# Altium schematic sheet Sheet1.SchDoc(Sheet1)
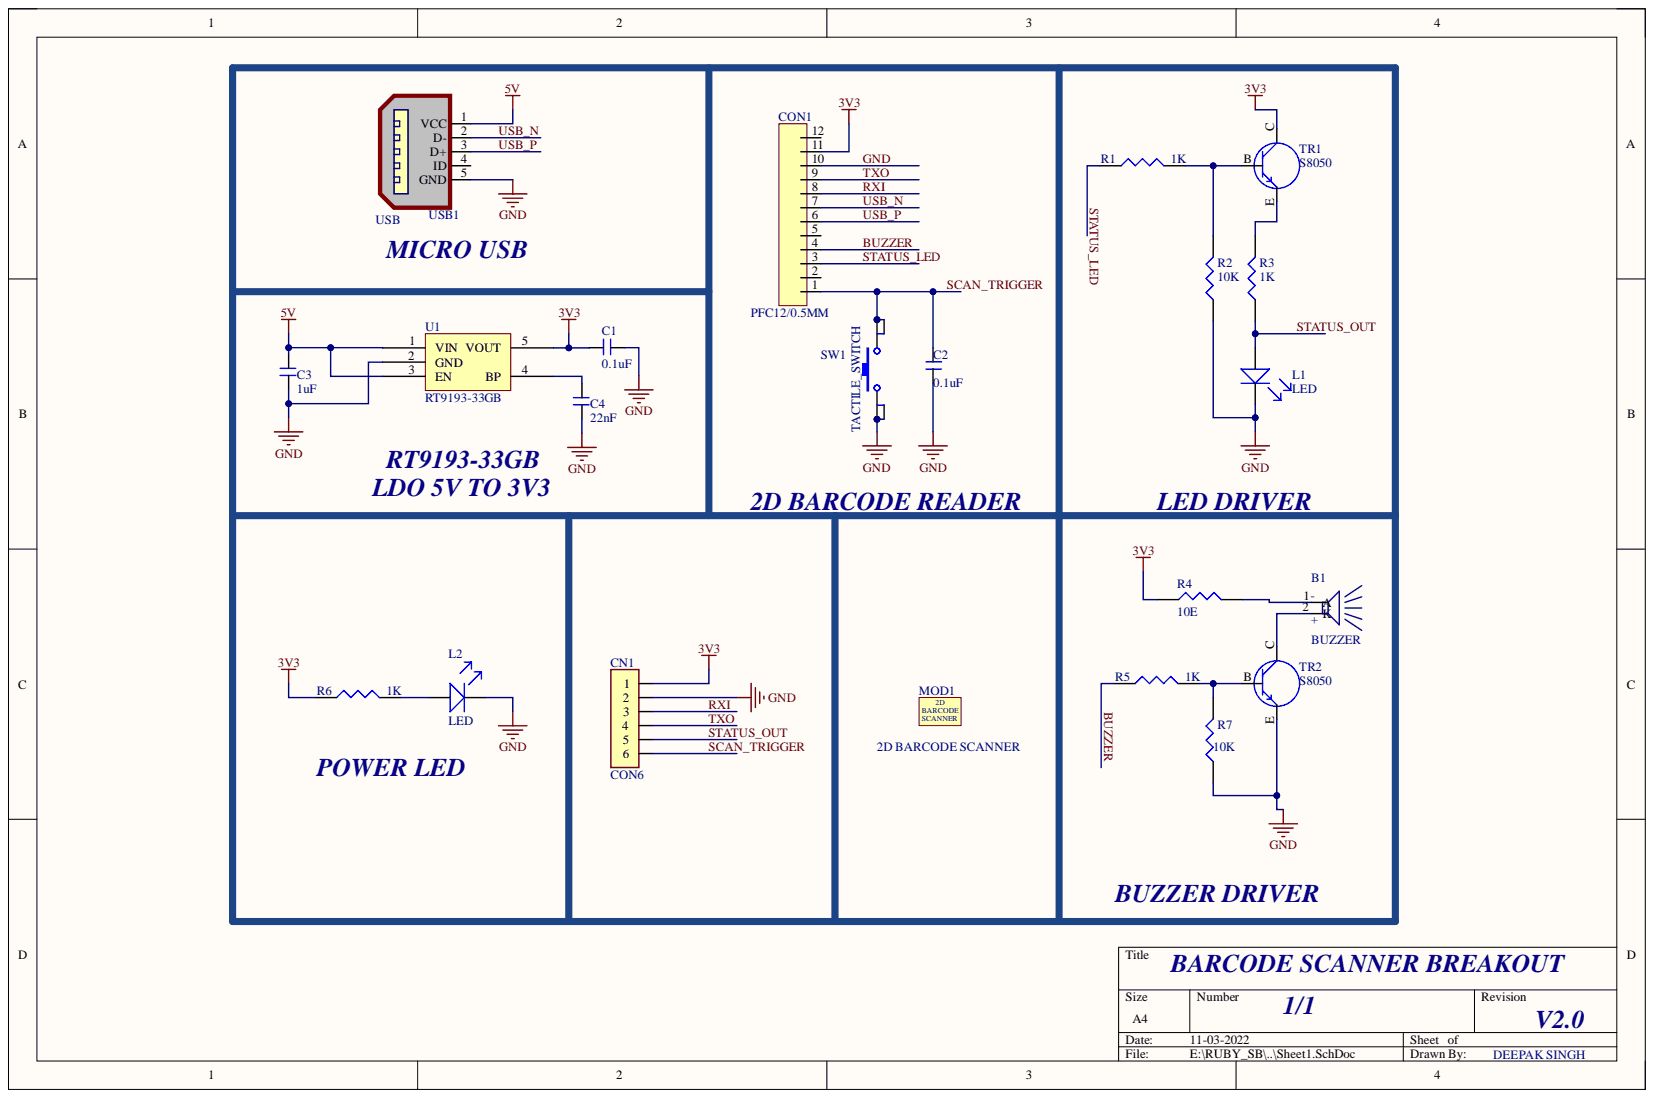
[diagram p0: Sheet1.SchDoc]

## Parts
B1  BUZZER  [BUZZER_9*3.2 SMD]
C1  0.1uF  [CAP_0603_NONPOLAR]
C2  0.1uF  [CAP_0603_NONPOLAR]
C3  1uF  [CAP_0603_NONPOLAR]
C4  22nF  [CAP_0603_NONPOLAR]
CN1  CON6  [CON6_BJ]
CON1  PFC12/0.5MM  [FPC CONNECTOR -12PIN]
L1  LED  [LED_0603_RED]
L2  LED  [LED_0603_RED]
MOD1  2D BARCODE SCANNER  [2D BARCODE SCANNER]
R1  1K  [0603]
R2  10K  [0603]
R3  1K  [0603]
R4  10E  [0603]
R5  1K  [0603]
R6  1K  [0603]
R7  10K  [0603]
SW1  TACTILE_SWITCH  [SKRPACE010]
TR1  S8050  [547_SOT23]
TR2  S8050  [547_SOT23]
U1  RT9193-33GB  [SOT-23-5]
USB1  USB  [USB_MICRO 2]

## Nets
3V3: C1.2 CN1.1 CON1.11 R4.1 R6.1 TR1.C U1.5(VOUT)
5V: C3.1 U1.1(VIN) U1.3(EN) USB1.1(VCC)
BUZZER: CON1.4 R5.1
GND: C1.1 C2.1 C3.2 C4.2 CN1.2 CON1.10 L1.K L2.K R2.1 R7.1 SW1.1 SW1.2 TR2.E U1.2(GND) USB1.5(GND)
RXI: CN1.3 CON1.8
SCAN_TRIGGER: C2.2 CN1.6 CON1.1 SW1.3 SW1.4
STATUS_LED: CON1.3 R1.1
STATUS_OUT: CN1.5 L1.A R3.1
TXO: CN1.4 CON1.9
USB_N: CON1.7 USB1.2(D-)
USB_P: CON1.6 USB1.3(D+)
NetB1_1: B1.1 R4.2
NetB1_2: B1.2 TR2.C
NetC4_1: C4.1 U1.4(BP)
NetL2_A: L2.A R6.2
NetR1_2: R1.2 R2.2 TR1.B
NetR3_2: R3.2 TR1.E
NetR5_2: R5.2 R7.2 TR2.B

Not connected: CON1 2 5 12; USB1 4(ID)

## Library data of the parts
B1 = BUZZER PIEZO: Component Kind: Standard; Library Name: dxp_pasl_lib.IntLib
C1-C4 = CAP_NONPOLAR: Component Kind: Standard; Library Name: dxp_pasl_lib.IntLib
R1-R7 = RES: Component Kind: Standard; Library Name: dxp_pasl_lib.IntLib
TR1 TR2 = TRANSISTER_NPN: Component Kind: Standard; Library Name: dxp_pasl_lib.IntLib
U1 = RT9193-33: Component Kind: Standard; Library Name: dxp_pasl_lib.IntLib
USB1 = USB_MICRO: Micro USB Connector SMD – TH Support Legs – 5 Pin – Type B; Component Kind: Standard; Library Name: dxp_pasl_lib.IntLib
CN1 = CON6: Component Kind: Standard; Library Name: dxp_pasl_lib.IntLib
CON1 = PFC12/0.5MM: Component Kind: Standard; Library Name: dxp_pasl_lib.IntLib
L1 L2 = LED: Component Kind: Standard; Library Name: dxp_pasl_lib.IntLib
MOD1 = 2D BARCODE SCANNER: Component Kind: Standard; Library Name: dxp_pasl_lib.IntLib
SW1 = TACTILE_SWITCH: Component Kind: Standard; Library Name: dxp_pasl_lib.IntLib
